# Altium schematic sheet foc1.SchDoc(foc1)
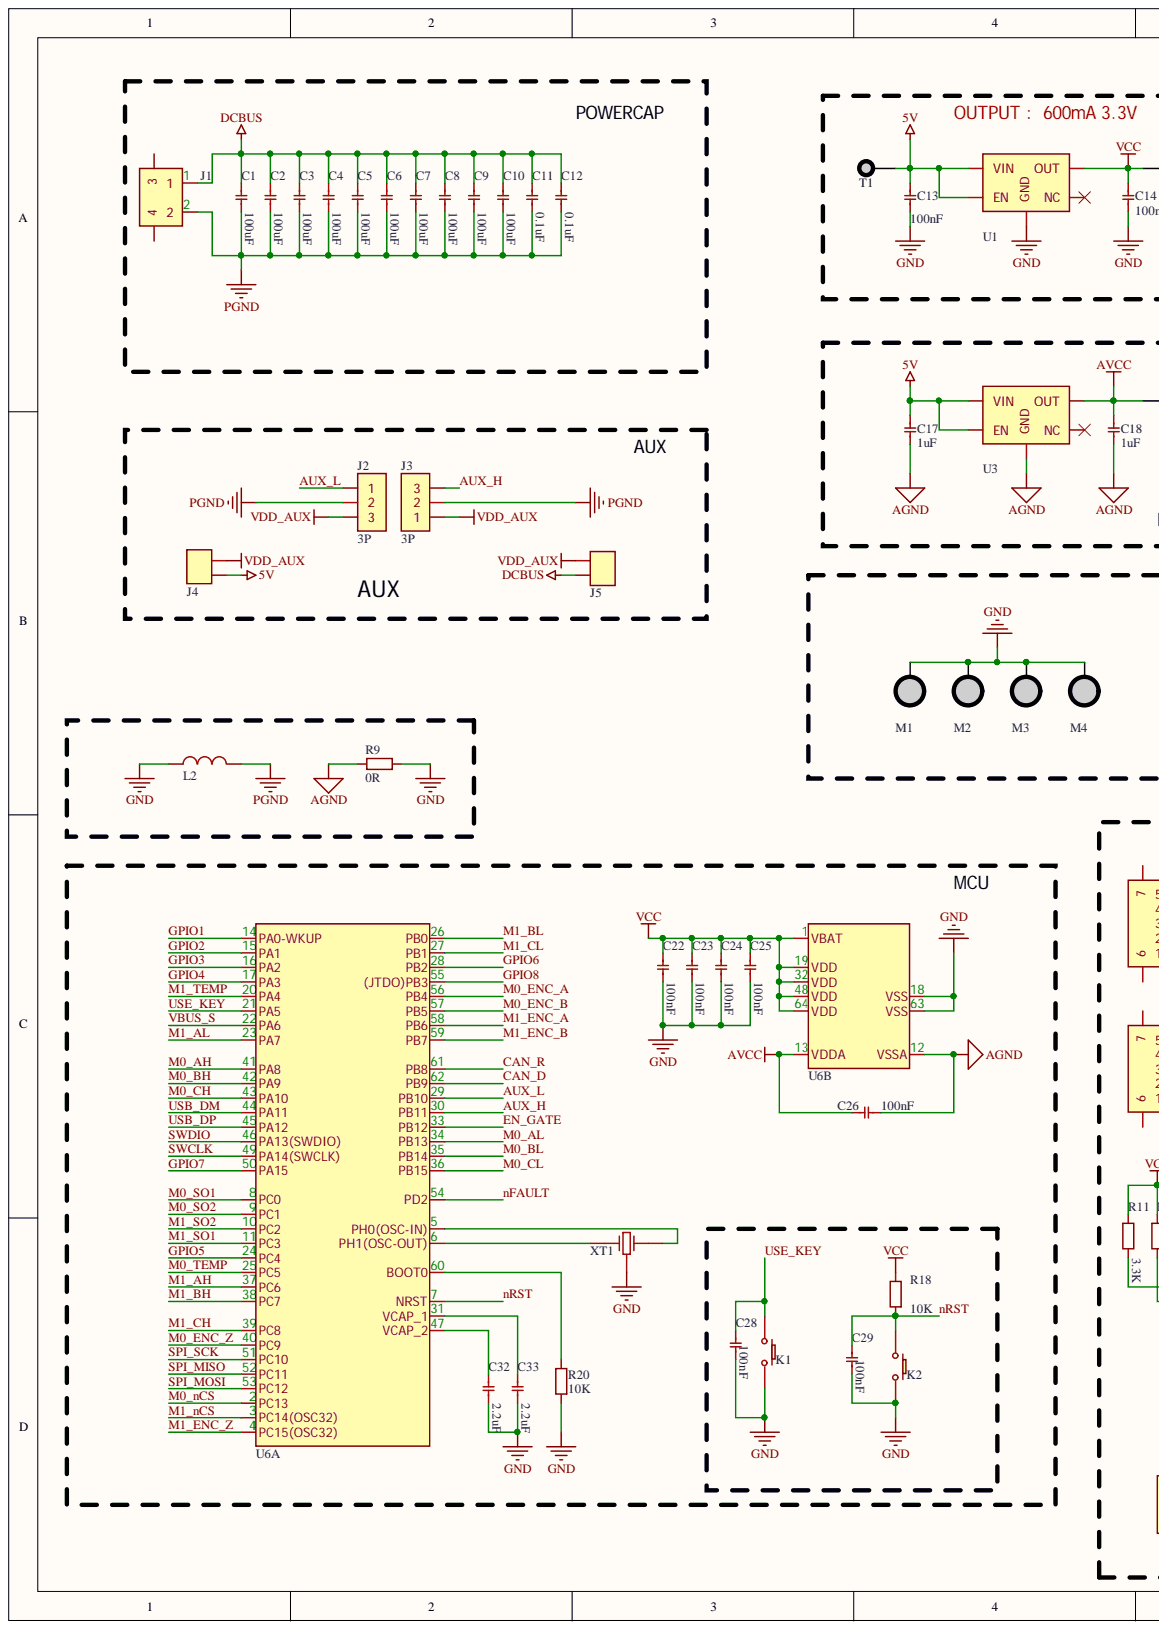
[diagram p0: foc1.SchDoc, left]
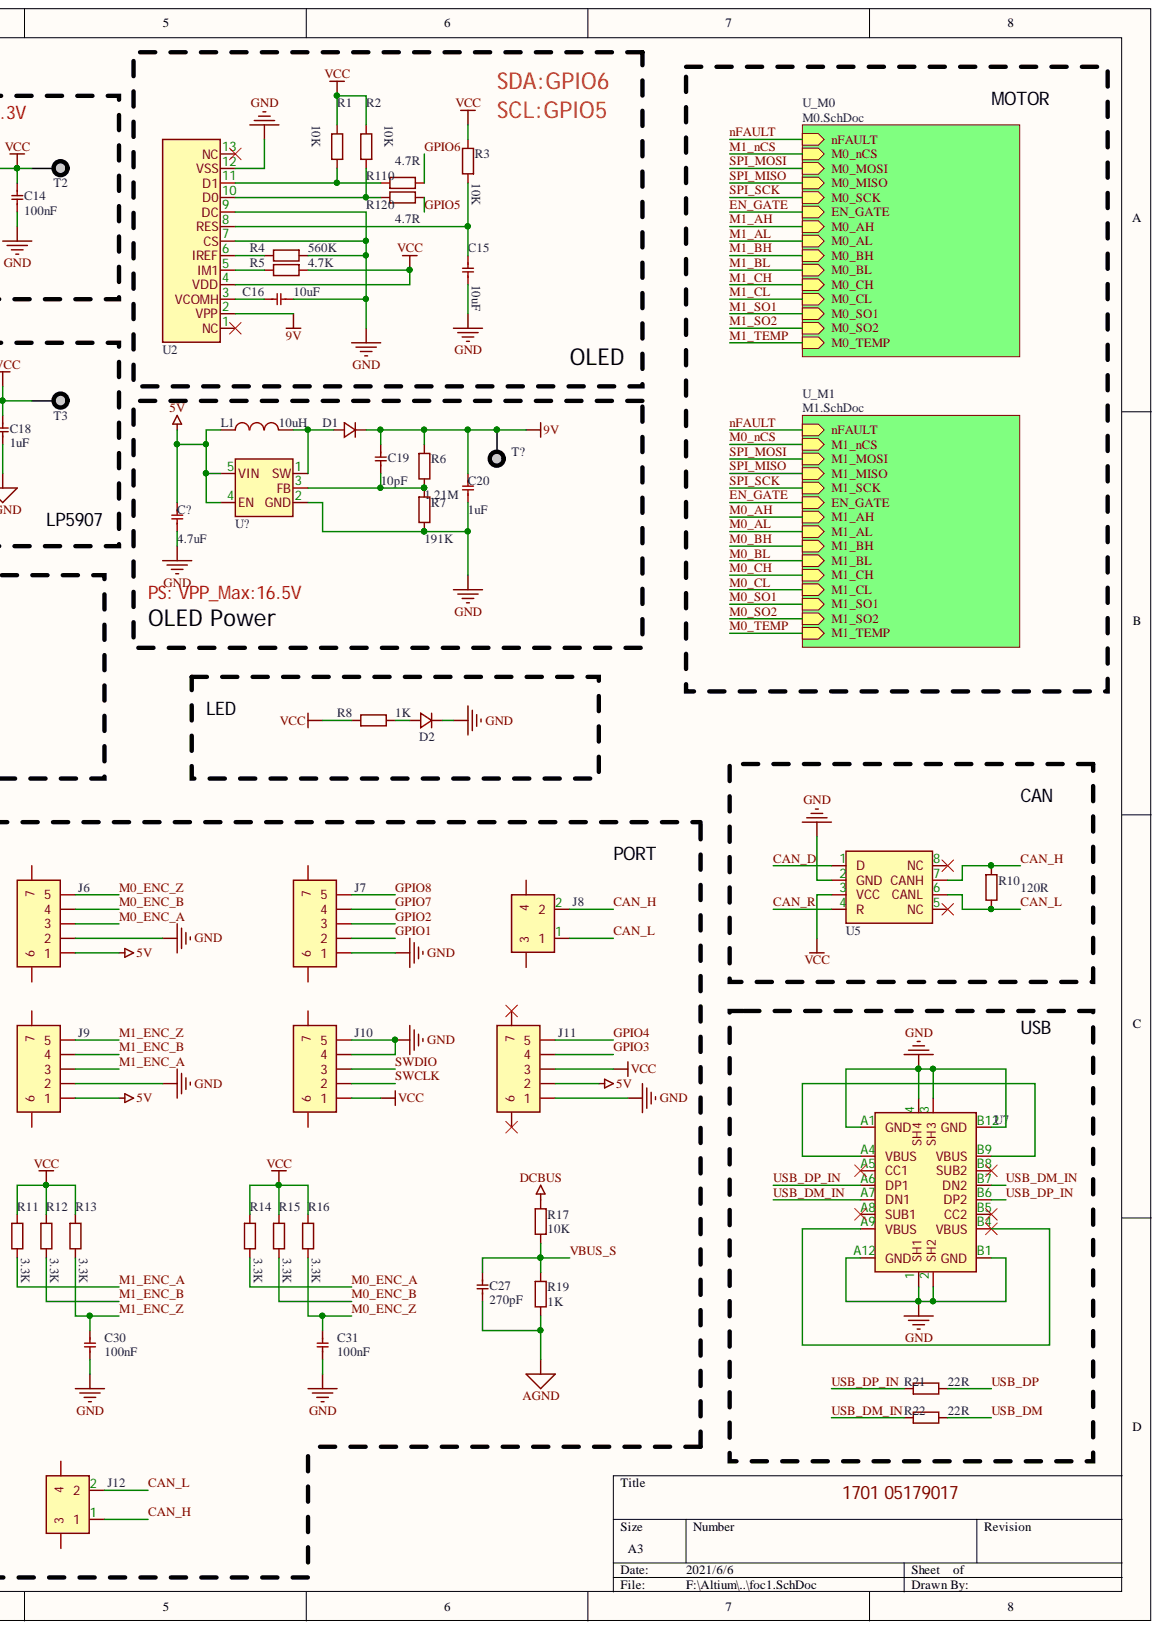
[diagram p1: foc1.SchDoc, right]

## Parts
C1  value 100uF  [1206_C]  (hidden comment: Container)
C2  value 100uF  [1206_C]  (hidden comment: Container)
C3  value 100uF  [1206_C]  (hidden comment: Container)
C4  value 100uF  [1206_C]  (hidden comment: Container)
C5  value 100uF  [1206_C]  (hidden comment: Container)
C6  value 100uF  [1206_C]  (hidden comment: Container)
C7  value 100uF  [1206_C]  (hidden comment: Container)
C8  value 100uF  [1206_C]  (hidden comment: Container)
C9  value 100uF  [1206_C]  (hidden comment: Container)
C10  value 100uF  [1206_C]  (hidden comment: Container)
C11  value 0.1uF  [0805_C]  (hidden comment: Container)
C12  value 0.1uF  [0805_C]  (hidden comment: Container)
C13  value 100nF  [0402_C]  (hidden comment: Container)
C14  value 100nF  [0402_C]  (hidden comment: Container)
C15  value 10uF  [0402_C]  (hidden comment: Container)
C16  value 10uF  [0402_C]  (hidden comment: Container)
C17  value 1uF  [0402_C]  (hidden comment: Container)
C18  value 1uF  [0402_C]  (hidden comment: Container)
C19  value 10pF  [0402_C]  (hidden comment: Container)
C20  value 1uF  [0402_C]  (hidden comment: Container)
C22  value 100nF  [0402_C]  (hidden comment: Container)
C23  value 100nF  [0402_C]  (hidden comment: Container)
C24  value 100nF  [0402_C]  (hidden comment: Container)
C25  value 100nF  [0402_C]  (hidden comment: Container)
C26  value 100nF  [0402_C]  (hidden comment: Container)
C27  value 270pF  [0402_C]  (hidden comment: Container)
C28  value 100nF  [0402_C]  (hidden comment: Container)
C29  value 100nF  [0402_C]  (hidden comment: Container)
C30  value 100nF  [0402_C]  (hidden comment: Container)
C31  value 100nF  [0402_C]  (hidden comment: Container)
C32  value 2.2uF  [0402_C]  (hidden comment: Container)
C33  value 2.2uF  [0402_C]  (hidden comment: Container)
C?  value 4.7uF  [0402_C]  (hidden comment: Container)
D1  Diode  [SOD-323]  (value not drawn)
D2  Diode  [0603_L]  (value not drawn)
J1  SH  [XT30PW-M]  (value not drawn)
J2  3P  [2.54PIN]
J3  3P  [2.54PIN]
J4  2PIN  [2PIN]  (value not drawn)
J5  2PIN  [2PIN]  (value not drawn)
J6  JST_1.0  [SH_1.0_5P]  (value not drawn)
J7  JST_1.0  [SH_1.0_5P]  (value not drawn)
J8  SH  [SH_1.0_2P]  (value not drawn)
J9  JST_1.0  [SH_1.0_5P]  (value not drawn)
J10  JST_1.0  [SH_1.0_5P]  (value not drawn)
J11  JST_1.0  [SH_1.0_5P]  (value not drawn)
J12  SH  [SH_1.0_2P]  (value not drawn)
K1  Key  [tortu_KEY]  (value not drawn)
K2  Key  [tortu_KEY]  (value not drawn)
L1  value 10uH  [1210]  (hidden comment: inductance)
L2  inductance  [1210]  (value not drawn)
M1  mount  [mounting]  (value not drawn)
M2  mount  [mounting]  (value not drawn)
M3  mount  [mounting]  (value not drawn)
M4  mount  [mounting]  (value not drawn)
R1  R  value 10K  [0402_R]
R2  R  value 10K  [0402_R]
R3  R  value 10K  [0402_R]
R4  R  value 560K  [0402_R]
R5  R  value 4.7K  [0402_R]
R6  R  value 1.21M  [0402_R]
R7  R  value 191K  [0402_R]
R8  R  value 1K  [0402_R]
R9  R  value 0R  [0402_R]
R10  R  value 120R  [0402_R]
R11  R  value 3.3K  [0402_R]
R12  R  value 3.3K  [0402_R]
R13  R  value 3.3K  [0402_R]
R14  R  value 3.3K  [0402_R]
R15  R  value 3.3K  [0402_R]
R16  R  value 3.3K  [0402_R]
R17  R  value 10K  [0402_R]
R18  R  value 10K  [0402_R]
R19  R  value 1K  [0402_R]
R20  R  value 10K  [0402_R]
R21  R  value 22R  [0402_R]
R22  R  value 22R  [0402_R]
R110  R  value 4.7R  [0402_R]
R120  R  value 4.7R  [0402_R]
T1  TestPin  [TestP]  (value not drawn)
T2  TestPin  [TestP]  (value not drawn)
T3  TestPin  [TestP]  (value not drawn)
T?  TestPin  [TestP]  (value not drawn)
U1  HX9193  [SOT-23-5]  (value not drawn)
U2  OLED  [1.3OLED]  (value not drawn)
U3  HX9193  [SOT-23-5]  (value not drawn)
U5  CAN  [SOIC-8]
U6  VPIN  [STM32F40X_LQFP-64]  (value not drawn)  (parts A,B)
U7  USB  [TYPE_C_16P]
U?  TPS  [SOT-23-5]  (value not drawn)
XT1  3213O  [3213]  (value not drawn)

## Nets
5V: C13.2 C17.2 C?.2 J4.1 J6.1 J9.1 J11.2 L1.1 T1.1 U1.1(VIN) U1.3(EN) U3.1(VIN) U3.3(EN) U?.4(EN) U?.5(VIN)
9V: C19.2 C20.2 D1.2 R6.2 T?.1 U2.2(VPP)
AGND: C17.1 C18.1 C26.1 C27.1 R9.2 R19.1 U3.2(GND) U6.12(VSSA)
AVCC: C18.2 C26.2 T3.1 U3.5(OUT) U6.13(VDDA)
DCBUS: C1.2 C2.2 C3.2 C4.2 C5.2 C6.2 C7.2 C8.2 C9.2 C10.2 C11.2 C12.2 J1.1 J5.2 R17.2
GND: C13.1 C14.1 C15.1 C16.1 C20.1 C22.1 C23.1 C24.1 C25.1 C28.1 C29.1 C30.1 C31.1 C32.1 C33.1 C?.1 D2.2 J6.2 J7.1 J9.2 J10.4 J10.5 J11.1 K1.2 K2.2 L2.1 M1.1 M2.1 M3.1 M4.1 R4.2 R7.1 R9.1 R20.2 U1.2(GND) U2.7(CS) U2.9(DC) U2.12(VSS) U5.2(GND) U6.18(VSS) U6.63(VSS) U7.1(SH1) U7.2(SH2) U7.3(SH3) U7.4(SH4) U7.A1(GND) U7.A12(GND) U7.B1(GND) U7.B12(GND) U?.2(GND) XT1.2
PGND: C1.1 C2.1 C3.1 C4.1 C5.1 C6.1 C7.1 C8.1 C9.1 C10.1 C11.1 C12.1 J1.2 J2.2 J3.2 L2.2
VCC: C14.2 C22.2 C23.2 C24.2 C25.2 J10.1 J11.3 R1.1 R2.1 R3.1 R5.2 R8.2 R11.2 R12.2 R13.2 R14.2 R15.2 R16.2 R18.1 T2.1 U1.5(OUT) U2.4(VDD) U5.3(VCC) U6.1(VBAT) U6.19(VDD) U6.32(VDD) U6.48(VDD) U6.64(VDD)
VDD_AUX: J2.3 J3.1 J4.2 J5.1
AUX_H: J3.3 U6.30(PB11)
AUX_L: J2.1 U6.29(PB10)
CAN_D: U5.1(D) U6.62(PB9)
CAN_H: J8.2 J12.1 R10.2 U5.7(CANH)
CAN_L: J8.1 J12.2 R10.1 U5.6(CANL)
CAN_R: U5.4(R) U6.61(PB8)
EN_GATE: U6.33(PB12)
GPIO1: J7.2 U6.14(PA0-WKUP)
GPIO2: J7.3 U6.15(PA1)
GPIO3: J11.4 U6.16(PA2)
GPIO4: J11.5 U6.17(PA3)
GPIO5: R120.1 U6.24(PC4)
GPIO6: R110.1 U6.28(PB2)
GPIO7: J7.4 U6.50(PA15)
GPIO8: J7.5 U6.55((JTDO)PB3)
M0_AH: U6.41(PA8)
M0_AL: U6.34(PB13)
M0_BH: U6.42(PA9)
M0_BL: U6.35(PB14)
M0_CH: U6.43(PA10)
M0_CL: U6.36(PB15)
M0_ENC_A: J6.3 R14.1 U6.56(PB4)
M0_ENC_B: J6.4 R15.1 U6.57(PB5)
M0_ENC_Z: C31.2 J6.5 R16.1 U6.40(PC9)
M0_nCS: U6.2(PC13)
M0_SO1: U6.8(PC0)
M0_SO2: U6.9(PC1)
M0_TEMP: U6.25(PC5)
M1_AH: U6.37(PC6)
M1_AL: U6.23(PA7)
M1_BH: U6.38(PC7)
M1_BL: U6.26(PB0)
M1_CH: U6.39(PC8)
M1_CL: U6.27(PB1)
M1_ENC_A: J9.3 R11.1 U6.58(PB6)
M1_ENC_B: J9.4 R12.1 U6.59(PB7)
M1_ENC_Z: C30.2 J9.5 R13.1 U6.4(PC15(OSC32))
M1_nCS: U6.3(PC14(OSC32))
M1_SO1: U6.11(PC3)
M1_SO2: U6.10(PC2)
M1_TEMP: U6.20(PA4)
nFAULT: U6.54(PD2)
nRST: C29.2 K2.1 R18.2 U6.7(NRST)
SPI_MISO: U6.52(PC11)
SPI_MOSI: U6.53(PC12)
SPI_SCK: U6.51(PC10)
SWCLK: J10.2 U6.49(PA14(SWCLK))
SWDIO: J10.3 U6.46(PA13(SWDIO))
USB_DM: R22.1 U6.44(PA11)
USB_DM_IN: R22.2 U7.A7(DN1) U7.B7(DN2)
USB_DP: R21.1 U6.45(PA12)
USB_DP_IN: R21.2 U7.A6(DP1) U7.B6(DP2)
USE_KEY: C28.2 K1.1 U6.21(PA5)
VBUS_S: C27.2 R17.1 R19.2 U6.22(PA6)
NetC15_2: C15.2 R3.2 U2.8(RES)
NetC16_2: C16.2 U2.3(VCOMH)
NetC19_1: C19.1 R6.1 R7.2 U?.3(FB)
NetC32_2: C32.2 U6.47(VCAP_2)
NetC33_2: C33.2 U6.31(VCAP_1)
NetD1_1: D1.1 L1.2 U?.1(SW)
NetD2_1: D2.1 R8.1
NetJ1_3: J1.3
NetJ1_4: J1.4
NetJ6_6: J6.6
NetJ6_7: J6.7
NetJ7_6: J7.6
NetJ7_7: J7.7
NetJ8_3: J8.3
NetJ8_4: J8.4
NetJ9_6: J9.6
NetJ9_7: J9.7
NetJ10_6: J10.6
NetJ10_7: J10.7
NetJ11_6: J11.6
NetJ11_7: J11.7
NetJ12_3: J12.3
NetJ12_4: J12.4
NetR1_2: R1.2 R110.2 U2.11(D1)
NetR2_2: R2.2 R120.2 U2.10(D0)
NetR4_1: R4.1 U2.6(IREF)
NetR5_1: R5.1 U2.5(IM1)
NetR20_1: R20.1 U6.60(BOOT0)
NetU1_4: U1.4(NC)
NetU2_1: U2.1(NC)
NetU2_13: U2.13(NC)
NetU3_4: U3.4(NC)
NetU5_5: U5.5(NC)
NetU5_8: U5.8(NC)
NetU6_5: U6.5(PH0(OSC-IN)) XT1.3
NetU6_6: U6.6(PH1(OSC-OUT)) XT1.1
NetU7_A4: U7.A4(VBUS) U7.B9(VBUS)
NetU7_A5: U7.A5(CC1)
NetU7_A8: U7.A8(SUB1)
NetU7_A9: U7.A9(VBUS) U7.B4(VBUS)
NetU7_B5: U7.B5(CC2)
NetU7_B8: U7.B8(SUB2)

## Library data of the parts
C1-C20 C22-C33 C? = C: Component Kind: Standard
  C1-C14 C17 C18 C22-C33: Library Name: mylibrary.IntLib
  C15 C16 C19 C20 C?: Library Name: mySchlib.SchLib
D1 = D: Value: 1N4148; Library Name: mylibrary.IntLib; Component Kind: Standard
D2 = D: Library Name: mylibrary.IntLib; Component Kind: Standard
J1 J8 J12 = Connect_sh_2P: Library Name: mylibrary.IntLib; Component Kind: Standard
J2 J3 = Connect_3p: Library Name: mylibrary.IntLib; Component Kind: Standard
J6 J7 J9-J11 = Connect_sh_5P: Library Name: mylibrary.IntLib; Component Kind: Standard
L1 L2 = L: Library Name: mylibrary.IntLib; Component Kind: Standard
M1-M4 = Mounting: Library Name: mySchlib.SchLib; Component Kind: Standard
U2 = 1.3OLED_128*64: Library Name: mylibrary.IntLib; Component Kind: Standard
U6 = STM32F40X_LQFP64: Library Name: mylibrary.IntLib; Component Kind: Standard
U7 = Type_c: Library Name: mylibrary.IntLib; Component Kind: Standard
U? = TPS61040DBV: Library Name: mylibrary.IntLib; Component Kind: Standard
XT1 = 3213: Library Name: mylibrary.IntLib; Component Kind: Standard
J4 J5 = 2PIN: Library Name: mylibrary.IntLib; Component Kind: Standard
K1 K2 = Key: Library Name: mylibrary.IntLib; Component Kind: Standard
R1-R22 R110 R120 = R: Component Kind: Standard
  R1-R7: Library Name: mySchlib.SchLib
  R8-R22 R110 R120: Library Name: mylibrary.IntLib
T1-T3 T? = TestPin: Library Name: mylibrary.IntLib; Component Kind: Standard
U1 U3 = HX9193: Library Name: mylibrary.IntLib; Component Kind: Standard
U5 = CAN: Library Name: mylibrary.IntLib; Component Kind: Standard
